# Altium schematic sheet Sensors.SchDoc
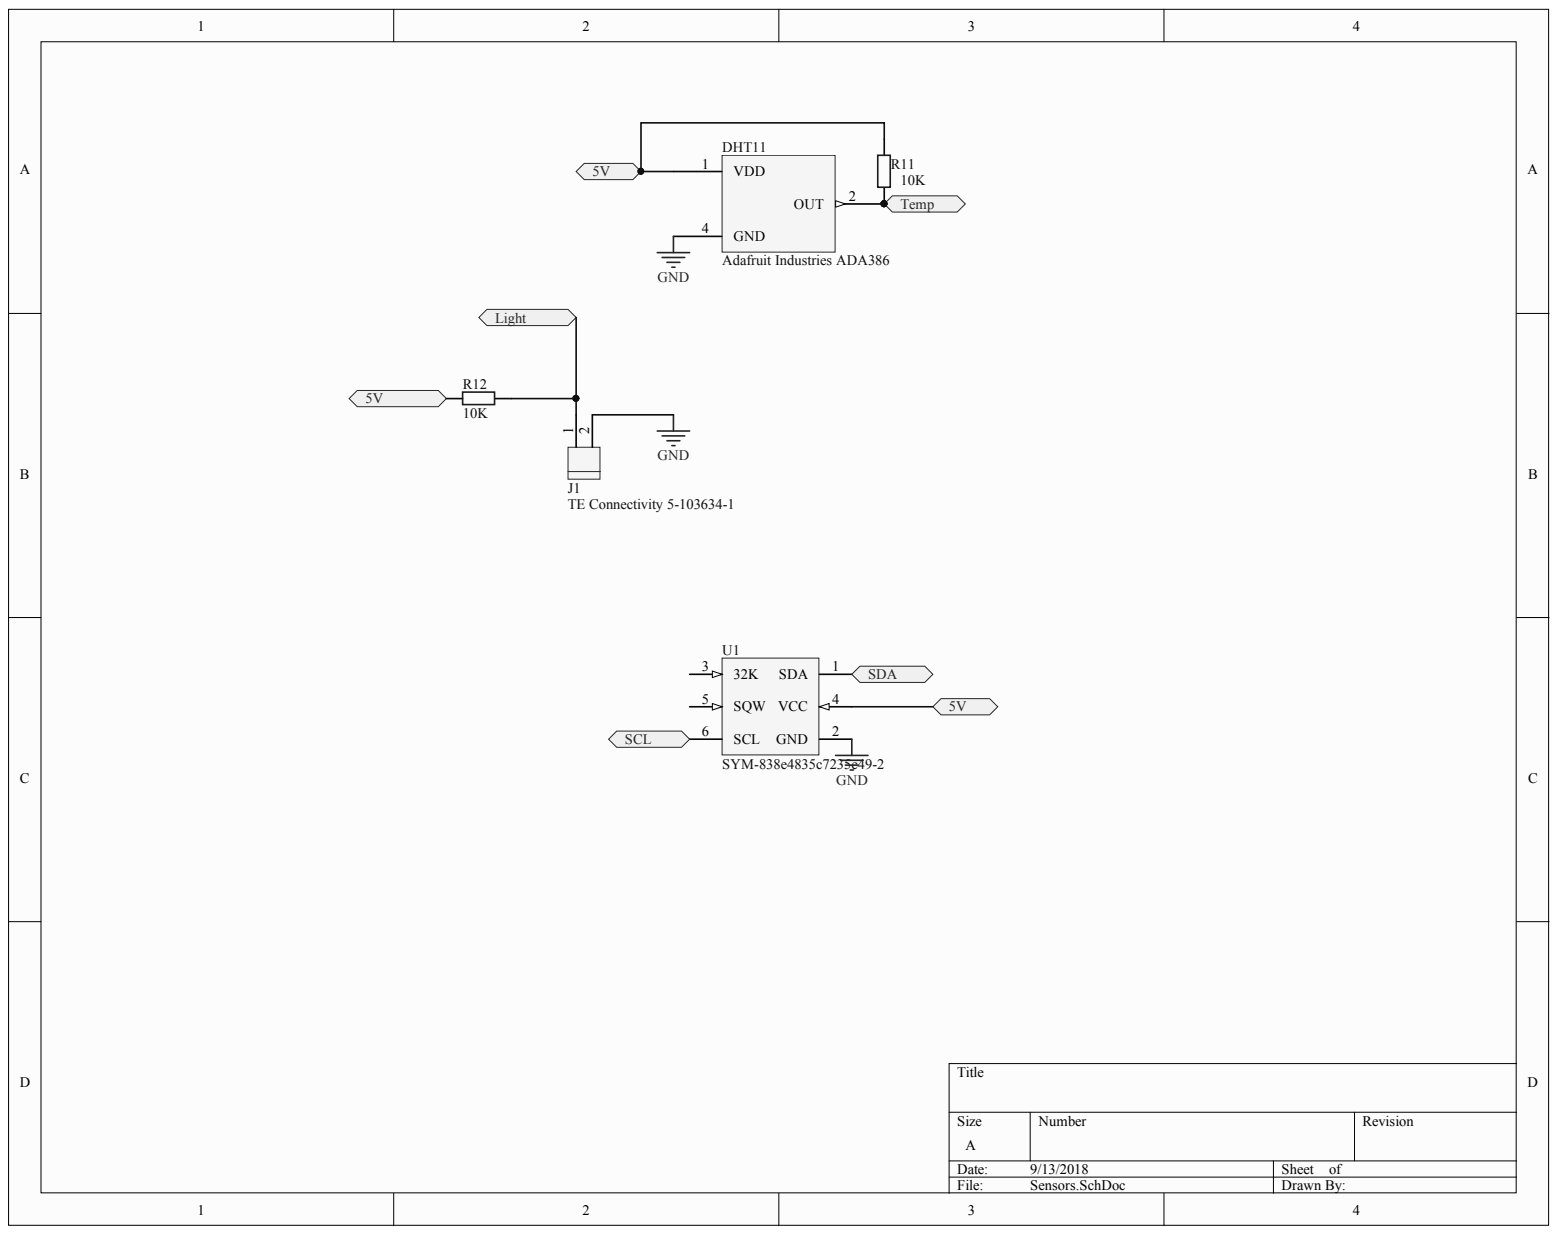
[diagram p0: Sensors.SchDoc]

## Parts
DHT11  Adafruit Industries ADA386  Manufacturer Part Number: ADA386  [DHT11]
J1  TE Connectivity 5-103634-1  [PCB-uaeje0c37wq92n4bv9j3-1]
R11  Ohms: 10K  [PCB-mctr3h3tvednl0z90ud0-1]  (hidden comment: Res2)
R12  Ohms: 10K  [PCB-mctr3h3tvednl0z90ud0-1]  (hidden comment: Res2)
U1  SYM-838e4835c7235c49-2  [PCB-y0s4izvblyw4j4eze3nd-1]

## Ports
5V, LIGHT, SCL, SDA, TEMP

## Nets
GND: DHT11.4 J1.2 U1.2(GND)
LIGHT: J1.1 R12.1 ; port LIGHT
TEMP: DHT11.2 R11.1 ; port TEMP
5V: DHT11.1 R11.2 R12.2 U1.4(VCC) ; port 5V
SCL: U1.6(SCL) ; port SCL
SDA: U1.1(SDA) ; port SDA

Not connected: U1 3(32K) 5(SQW)

## Library data of the parts
DHT11 = CMP-f2473ad10e112d80-1: DHT11 basic temperature-humidity sensor + extras; Component Kind: Standard; Library Name: CommunityVault; OctopartID: f2473ad10e112d80; Octopart URL: http://octopart.com/ada386-adafruit+industries-27056432; RoHS: Compliant
J1 = CMP-6cb9a7e820d6b37e-1: CONN HEADER RTANG 2POS PCB TIN; ELV Compliant: Compliant; Lead-Free Status: Lead Free; Number of Contacts: 2; Pin Pitch: 2.54 mm; Color: Black; Component Kind: Standard; Contact Material: Brass; Contact Plating: Tin; Housing Material: Thermoplastic; Library Name: CommunityVault; Lifecycle Status: Active; Mounting Style: Through Hole; Number of Rows: 1; OctopartID: 6cb9a7e820d6b37e; Octopart URL: http://octopart.com/5-103634-1-te+connectivity-39545097; REACH SVHC Compliance: No SVHC; Size-Height: 5.08 mm; Size-Width: 13.6 mm
R11 R12 = CMP-1413dd18cda2cbd4-1: Resistor; Carbon Composition; Res 3.9 Kilohms; Pwr-Rtg 0.25 W; Tol 5%; Axial; Manufacturer Part Number: OD392JE; Lead-Free Status: Lead Free; Power Rating: 250 mW; Component Kind: Standard; Library Name: CommunityVault; Mounting Style: Through Hole, Axial; OctopartID: 1413dd18cda2cbd4; Resistance Tolerance: ±5%; Size-Diameter: 2.50 mm
U1 = CMP-838e4835c7235c49-3: Peripheral Module, DS3231M I2C Real-Time Clock; Manufacturer Part Number: DS3231MPMB1#; Component Kind: Standard; Library Name: CommunityVault; OctopartID: 838e4835c7235c49; RoHS: Exempt
